# Altium schematic sheet Sheet2.SchDoc
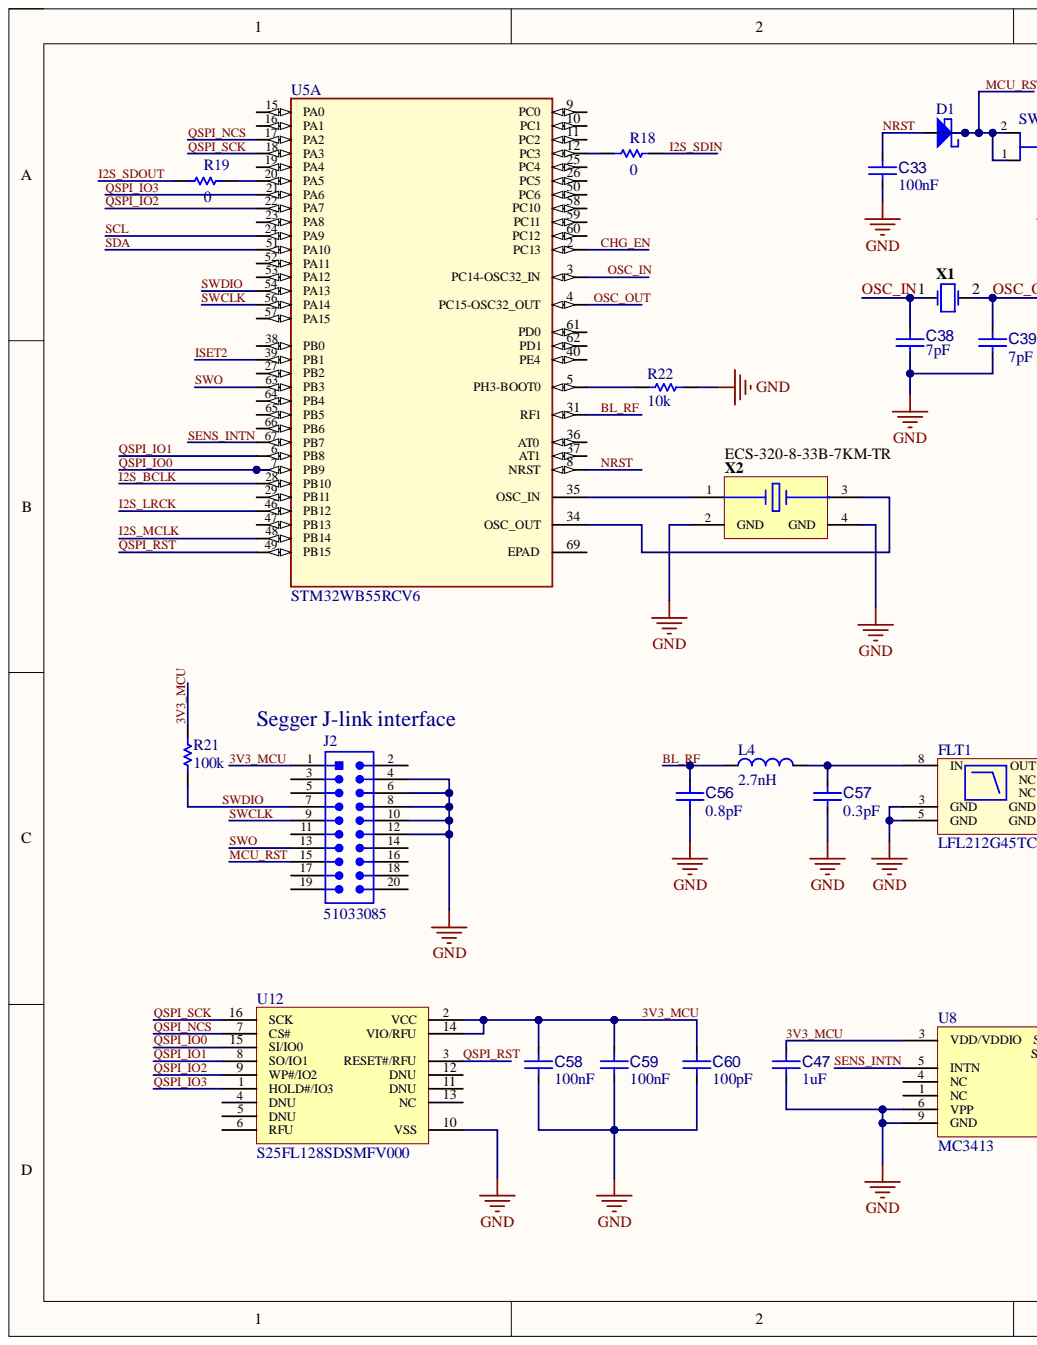
[diagram p0: Sheet2.SchDoc, left]
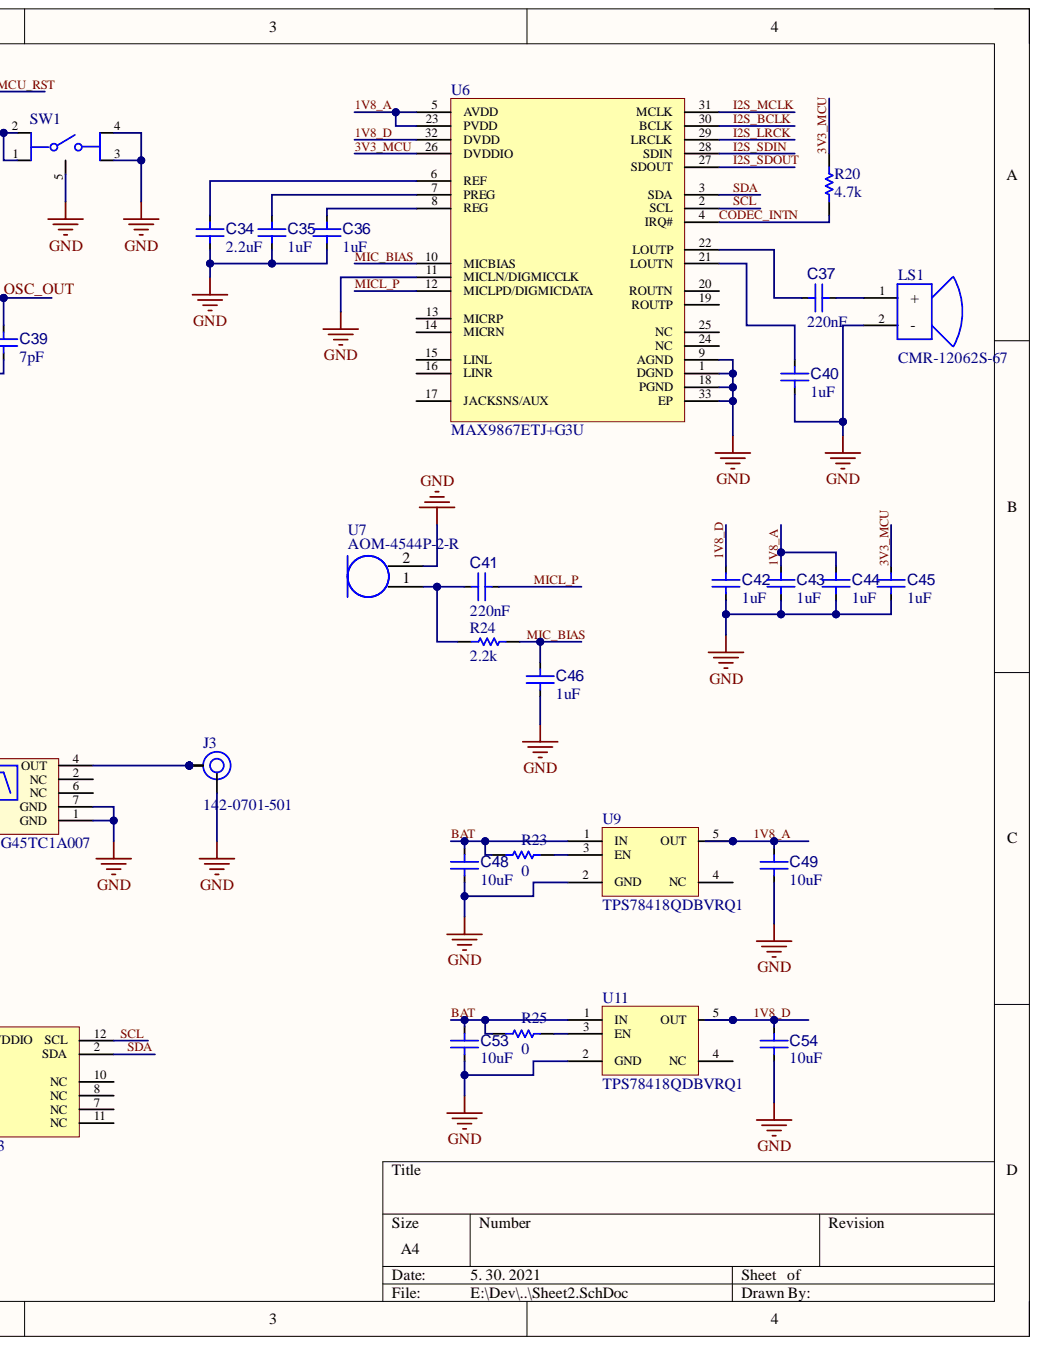
[diagram p1: Sheet2.SchDoc, right]

## Parts
C33  value 100nF  [0402-SMD-CAP]  (hidden comment: EMF105B7104MVHF)
C34  value 2.2uF  Capacitance: 2.2uF  [0603-SMD-CAP]  (hidden comment: GRM188R71A225KE15D)
C35  value 1uF  Capacitance: 1uF  [0603-SMD-CAP]  (hidden comment: C0603C105K3PACTU)
C36  value 1uF  Capacitance: 1uF  [0603-SMD-CAP]  (hidden comment: C0603C105K3PACTU)
C37  value 220nF  Capacitance: 220nF  [0402-SMD-CAP]  (hidden comment: GRM155R71C224KA12D)
C38  value 7pF  Capacitance: 7pF  [0402-SMD-CAP]  (hidden comment: 04025A7R0CAT2A)
C39  value 7pF  Capacitance: 7pF  [0402-SMD-CAP]  (hidden comment: 04025A7R0CAT2A)
C40  value 1uF  Capacitance: 1uF  [0603-SMD-CAP]  (hidden comment: C0603C105K3PACTU)
C41  value 220nF  Capacitance: 220nF  [0402-SMD-CAP]  (hidden comment: GRM155R71C224KA12D)
C42  value 1uF  Capacitance: 1uF  [0603-SMD-CAP]  (hidden comment: C0603C105K3PACTU)
C43  value 1uF  Capacitance: 1uF  [0603-SMD-CAP]  (hidden comment: C0603C105K3PACTU)
C44  value 1uF  Capacitance: 1uF  [0603-SMD-CAP]  (hidden comment: C0603C105K3PACTU)
C45  value 1uF  Capacitance: 1uF  [0603-SMD-CAP]  (hidden comment: C0603C105K3PACTU)
C46  value 1uF  Capacitance: 1uF  [0603-SMD-CAP]  (hidden comment: C0603C105K3PACTU)
C47  value 1uF  Capacitance: 1uF  [0603-SMD-CAP]  (hidden comment: C0603C105K3PACTU)
C48  value 10uF  Capacitance: 10uF  [0805-SMD-CAP]  (hidden comment: C0805C106K8PACTU)
C49  value 10uF  Capacitance: 10uF  [0805-SMD-CAP]  (hidden comment: C0805C106K8PACTU)
C53  value 10uF  Capacitance: 10uF  [0805-SMD-CAP]  (hidden comment: C0805C106K8PACTU)
C54  value 10uF  Capacitance: 10uF  [0805-SMD-CAP]  (hidden comment: C0805C106K8PACTU)
C56  value 0.8pF  Capacitance: 0.8pF  [0402-SMD-CAP]  (hidden comment: GJM1555C1HR80BB01D)
C57  value 0.3pF  Capacitance: 0.3pF  [0402-SMD-CAP]  (hidden comment: GJM1555C1HR30WB01D)
C58  value 100nF  [0402-SMD-CAP]  (hidden comment: EMF105B7104MVHF)
C59  value 100nF  [0402-SMD-CAP]  (hidden comment: EMF105B7104MVHF)
C60  value 100pF  Capacitance: 100pF  [0402-SMD-CAP]  (hidden comment: 04025A101JAT2A)
D1  SS12-E3/61T  [VISH-DO-214AC_V]  (value not drawn)
FLT1  LFL212G45TC1A007  [LFL212G45TC1A007-Footprint-1]
J2  51033085  [51033085-Footprint-1]
J3  142-0701-501  [142-0701-501-Footprint-1]
L4  value 2.7nH  Inductance: 2.7nH  [0402-SMD-IND]  (hidden comment: LQG15HS2N7S02D)
LS1  CMR-12062S-67  [CMR-12062S-67-Footprint-1]
R18  value 0  [0603-SMD-RES]  (hidden comment: Jumper 0603)
R19  value 0  [0603-SMD-RES]  (hidden comment: Jumper 0603)
R20  value 4.7k  Resistance: 4.7k  [0603-SMD-RES]  (hidden comment: ERJ-3EKF4701V)
R21  value 100k  Resistance: 100k  [0603-SMD-RES]  (hidden comment: Resistor 100k +/-1% 0603 100 mW)
R22  value 10k  [0402_SMD_RES]  (hidden comment: CRCW040210K0FKTD)
R23  value 0  [0603-SMD-RES]  (hidden comment: Jumper 0603)
R24  value 2.2k  [0603-SMD-RES]  (hidden comment: CRCW06032K20FKEA)
R25  value 0  [0603-SMD-RES]  (hidden comment: Jumper 0603)
SW1  KMR231GLFS  [FP-KMR231GLFS-MFG]  (value not drawn)
U5  STM32WB55RCV6  [QFN40P800X800X100_HS-69N]  (part A)
U6  MAX9867ETJ+G3U  [QFN50P500X500X80_HS-33N]
U7  AOM-4544P-2-R  [AOM-4544P-2-R-Footprint-1]
U8  MC3413  [MC3413 -Footprint-1]
U9  TPS78418QDBVRQ1  [SOT23127P600-8N]
U11  TPS78418QDBVRQ1  [SOT23127P600-8N]
U12  S25FL128SDSMFV000  [SOIC127P1030X265-16M]
X1  ECS-.327-7-12-TR  [ECS-.327-7-12-TR-Footprint-1]  (value not drawn)
X2  ECS-320-8-33B-7KM-TR  [ECS-320-8-33B-7KM-TR-Footprint-1]

## Nets
GND: C33.2 C34.2 C35.2 C36.2 C38.1 C39.1 C40.2 C42.2 C43.2 C44.2 C45.2 C46.2 C47.2 C48.2 C49.2 C53.2 C54.2 C56.2 C57.2 C58.2 C59.2 C60.2 FLT1.1(GND) FLT1.3(GND) FLT1.5(GND) FLT1.7(GND) J2.4 J2.6 J2.8 J2.10 J2.12 J3.1 LS1.2 R22.1 SW1.3 SW1.4 SW1.5 U6.1(DGND) U6.9(AGND) U6.11(MICLN/DIGMICCLK) U6.18(PGND) U6.33(EP) U7.2 U8.6(VPP) U8.9(GND) U9.2(GND) U11.2(GND) U12.10(VSS) X2.2(GND) X2.4(GND)
1V8_A: C43.1 C44.1 C49.1 U6.5(AVDD) U6.23(PVDD) U9.5(OUT)
1V8_D: C42.1 C54.1 U6.32(DVDD) U11.5(OUT)
3V3_MCU: C45.1 C47.1 C58.1 C59.1 C60.1 J2.1 R20.2 R21.2 U6.26(DVDDIO) U8.3(VDD/VDDIO) U12.2(VCC) U12.14(VIO/RFU)
BAT: C48.1 C53.1 R23.1 R25.1 U9.1(IN) U11.1(IN)
BL_RF: C56.1 L4.1 U5.31(RF1)
CHG_EN: U5.2(PC13)
CODEC_INTN: R20.1 U6.4(IRQ#)
I2S_BCLK: U5.28(PB10) U6.30(BCLK)
I2S_LRCK: U5.46(PB12) U6.29(LRCLK)
I2S_MCLK: U5.48(PB14) U6.31(MCLK)
I2S_SDIN: R18.2 U6.28(SDIN)
I2S_SDOUT: R19.1 U6.27(SDOUT)
ISET2: U5.39(PB1)
MCU_RST: D1.2 J2.15 SW1.1 SW1.2
MIC_BIAS: C46.1 R24.2 U6.10(MICBIAS)
MICL_P: C41.2 U6.12(MICLPD/DIGMICDATA)
NRST: C33.1 D1.1 U5.8(NRST)
OSC_IN: C38.2 U5.3(PC14-OSC32_IN) X1.1
OSC_OUT: C39.2 U5.4(PC15-OSC32_OUT) X1.2
QSPI_IO0: U5.7(PB9) U12.15(SI/IO0)
QSPI_IO1: U5.6(PB8) U12.8(SO/IO1)
QSPI_IO2: U5.22(PA7) U12.9(WP#/IO2)
QSPI_IO3: U5.21(PA6) U12.1(HOLD#/IO3)
QSPI_NCS: U5.17(PA2) U12.7(CS#)
QSPI_RST: U5.49(PB15) U12.3(RESET#/RFU)
QSPI_SCK: U5.18(PA3) U12.16(SCK)
SCL: U5.24(PA9) U6.2(SCL) U8.12(SCL)
SDA: U5.51(PA10) U6.3(SDA) U8.2(SDA)
SENS_INTN: U5.67(PB7) U8.5(INTN)
SWCLK: J2.9 U5.56(PA14)
SWDIO: J2.7 R21.1 U5.54(PA13)
SWO: J2.13 U5.63(PB3)
NetC34_1: C34.1 U6.6(REF)
NetC35_1: C35.1 U6.7(PREG)
NetC36_1: C36.1 U6.8(REG)
NetC37_1: C37.1 U6.22(LOUTP)
NetC37_2: C37.2 LS1.1
NetC40_1: C40.1 U6.21(LOUTN)
NetC41_1: C41.1 R24.1 U7.1
NetC57_1: C57.1 FLT1.8(IN) L4.2
NetFLT1_4: FLT1.4(OUT) J3.2 J3.3 J3.4 J3.5
NetR18_1: R18.1 U5.12(PC3)
NetR19_2: R19.2 U5.20(PA5)
NetR22_2: R22.2 U5.5(PH3-BOOT0)
NetR23_2: R23.2 U9.3(EN)
NetR25_2: R25.2 U11.3(EN)
NetU5_34: U5.34(OSC_OUT) X2.3
NetU5_35: U5.35(OSC_IN) X2.1

Not connected: FLT1 2(NC) 6(NC); J2 2 3 5 11 14 16 17 18 19 20; U5 9(PC0) 10(PC1) 11(PC2) 15(PA0) 16(PA1) 19(PA4) 23(PA8) 25(PC4) 26(PC5) 27(PB2) 29(PB11) 36(AT0) 37(AT1) 38(PB0) 40(PE4) 47(PB13) 50(PC6) 52(PA11) 53(PA12) 57(PA15) 58(PC10) 59(PC11) 60(PC12) 61(PD0) 62(PD1) 64(PB4) 65(PB5) 66(PB6) 69(EPAD); U6 13(MICRP) 14(MICRN) 15(LINL) 16(LINR) 17(JACKSNS/AUX) 19(ROUTP) 20(ROUTN) 24(NC) 25(NC); U8 1(NC) 4(NC) 7(NC) 8(NC) 10(NC) 11(NC); U9 4(NC); U11 4(NC); U12 4(DNU) 5(DNU) 6(RFU) 11(DNU) 12(DNU) 13(NC)

## Library data of the parts
C33 C58 C59 = CMP-001-00001-14: Chip Capacitor, 0.1 uF, +/- 5%, 16 V, 0402 (1005 Metric); Capacitor Type: Ceramic; Voltage Rating: 16V; Case/Package: 0402; Component Kind: Standard; Max Operating Temperature: 125°C; Mfr. Part No.: EMF105B7104MVHF; Min Operating Temperature: -55°C; Mounting Technology: SM; Pins: 2; RoHS Compliant: True; Tolerance: 5%; Library Name: Budapest University of Technology and Economics
C34 = CMP-001-00083-9: CAP CER 2.2UF 10V X7R 0603; Material: Ceramic; Tolerance: 10%; Case Code (Imperial): 0603; Case Code (Metric): 1608; Case/Package: 0603; Component Kind: Standard; Depth: 0.8mm; Dielectric: X7R; Height: 0.8mm; Lead Free: Lead Free; Length: 1.6mm; Max Operating Temperature: 125°C; Min Operating Temperature: -55°C; Mount: Surface Mount; Number of Pins: 2; Package Quantity: 4000; Packaging: Cut Tape; REACH SVHC: No SVHC; RoHS Compliant: Yes; Series: GRM; Termination: Standard; Thickness: 0.035inch; Voltage: 10V; Voltage Rating: 10V; Voltage Rating (DC): 10V; Weight: 0.000222oz; Width: 0.8mm; Library Name: Budapest University of Technology and Economics
C35 C36 C40 C42-C47 = CMP-001-00097-3: KEMET - C0603C105K3PACTU - SMD Multilayer Ceramic Capacitor, 1 µF, 25 V, 0603 [1608 Metric], ± 10%, X5R, C Series KEMET; Voltage: 25V; Case Code (Imperial): 0603; Case Code (Metric): 1608; Case/Package: 0603; Component Kind: Standard; Construction: Flat; Contact Plating: Tin,Matte; Depth: 0.8mm; Dielectric: X5R; Dielectric Material: Ceramic; Height: 0.8mm; Lead Free: Lead Free; Length: 1.6mm; Max Operating Temperature: 85°C; Mfr. Part No.: C0603C105K3PACTU; Min Operating Temperature: -55°C; Mount: Surface Mount,PCB; Number of Pins: 2; Package Quantity: 4000; Packaging: Tape and Reel; Radiation Hardening: No; REACH SVHC: No SVHC; RoHS Compliant: Yes; Series: C0603C; Termination: SMD/SMT; Thickness: 0.034inch; Tolerance: 10%; Voltage Rating: 25V; Voltage Rating (DC): 25V; Width: 0.031inch; Library Name: Budapest University of Technology and Economics
C37 C41 = CMP-001-00090-10: MURATA - GRM155R71C224KA12D - SMD Multilayer Ceramic Capacitor, 0.22 µF, 16 V, 0402 [1005 Metric], ± 10%, X7R, GRM Series; Voltage: 16V; Case Code (Imperial): 0402; Case Code (Metric): 1005; Case/Package: 0402; Component Kind: Standard; Construction: Flat; Depth: 0.5mm; Dielectric: X7R; Height: 0.5mm; Lead Free: Lead Free; Length: 1mm; Material: Ceramic; Max Operating Temperature: 125°C; Min Operating Temperature: -55°C; Mount: Surface Mount; Package Quantity: 1; Packaging: Tape and Reel; Radiation Hardening: No; REACH SVHC: No SVHC; RoHS Compliant: Yes; Series: GRM; Termination: SMD/SMT; Thickness: 0.022inch; Tolerance: 10%; Voltage Rating: 16V; Voltage Rating (DC): 16V; Width: 0.02inch; Library Name: Budapest University of Technology and Economics
C38 C39 = CMP-001-00007-1: Cap Ceramic 7pF 50V C0G 0.25pF SMD 0402 125C T/R; Temperature Coefficient: 30ppm/°C; Case Code (Imperial): 0402; Case Code (Metric): 1005; Case/Package: 0402; Component Kind: Standard; Construction: Flat; Depth: 0.5mm; Dielectric: C0G; Dielectric Material: Ceramic; Lead Free: Lead Free; Length: 1mm; Max Operating Temperature: 125°C; Mfr. Part No.: 04025A7R0CAT2A; Min Operating Temperature: -55°C; Mount: Surface Mount; Package Quantity: 10000; Packaging: Tape and Reel; Radiation Hardening: No; REACH SVHC: No SVHC; Series: -; Termination: SMD/SMT; Thickness: 0.022inch; Voltage: 50V; Voltage Rating: 50V; Voltage Rating (DC): 50V; Width: 0.02inch; Library Name: Budapest University of Technology and Economics
C48 C49 C53 C54 = CMP-001-00096-2: CAP CER 10UF 10V X5R 0805; Dielectric Material: Ceramic; Dissipation Factor: 10%; Case Code (Imperial): 0805; Case Code (Metric): 2012; Case/Package: 0805; Component Kind: Standard; Construction: Flat; Contact Plating: Tin,Matte; Depth: 1.25mm; Dielectric: X5R; Height: 1.25mm; Length: 2mm; Max Operating Temperature: 85°C; Min Operating Temperature: -55°C; Mount: Surface Mount; Number of Pins: 2; Package Quantity: 1; Packaging: Tape and Reel; Radiation Hardening: No; REACH SVHC: No SVHC; RoHS Compliant: Yes; Series: C; Termination: SMD/SMT; Thickness: 0.055inch; Tolerance: 10%; Voltage: 10V; Voltage Rating: 10V; Voltage Rating (DC): 10V; Width: 1.2mm; Library Name: Budapest University of Technology and Economics
C56 = CMP-001-00009-1: Cap Ceramic 0.8pF 50V C0G 0.1pF SMD 0402 125C Paper T/R; Case Code (Imperial): 0402; Case Code (Metric): 1005; Case/Package: 0402; Component Kind: Standard; Construction: Flat; Depth: 0.5mm; Dielectric: C0G; Height: 0.5mm; Lead Free: Lead Free; Length: 1mm; Max Operating Temperature: 125°C; Mfr. Part No.: GJM1555C1HR80BB01D; Min Operating Temperature: -55°C; Mount: Surface Mount; Package Quantity: 1; Packaging: Tape and Reel; Radiation Hardening: No; RoHS Compliant: Yes; Series: GJM; Termination: SMD/SMT; Thickness: 0.022inch; Voltage: 50V; Voltage Rating: 50V; Voltage Rating (DC): 50V; Width: 0.02inch; Library Name: Budapest University of Technology and Economics
C57 = CMP-001-00008-1: Multilayer Ceramic Capacitors MLCC - SMD/SMT 0402 0.3pF 50Volts C0G +/-0.05pF; Voltage Rating: 50V; Case Code (Imperial): 0402; Case Code (Metric): 1005; Case/Package: 0402; Component Kind: Standard; Construction: Flat; Depth: 0.5mm; Dielectric: C0G; Height: 0.5mm; Lead Free: Lead Free; Length: 1mm; Max Operating Temperature: 125°C; Mfr. Part No.: GJM1555C1HR30WB01D; Min Operating Temperature: -55°C; Mount: Surface Mount; Package Quantity: 10000; Packaging: Tape and Reel; Radiation Hardening: No; RoHS Compliant: Yes; Series: GJM; Termination: SMD/SMT; Thickness: 0.022inch; Voltage Rating (DC): 50V; Width: 0.02inch; Library Name: Budapest University of Technology and Economics
C60 = CMP-001-00004-1: Capacitor, Ceramic; 100pf; Chip; Case 0402; Npo; +/-5%; 50wvdc; Smd; 2.5% Df; Tr; Dielectric: C0G; Insulation Resistance: 1GR; Temperature Coefficient: 30ppm/°C; Tolerance: 5%; Voltage: 50V; Case Code (Imperial): 0402; Case Code (Metric): 1005; Case/Package: 0402; Component Kind: Standard; Construction: Flat; Contact Plating: Tin,Matte; Depth: 0.5mm; Dissipation Factor: 2.5%; Height: 0.56mm; Lead Free: Lead Free; Length: 1mm; Max Operating Temperature: 125°C; Mfr. Part No.: 04025A101JAT2A; Min Operating Temperature: -55°C; Mount: Surface Mount,PCB; Package Quantity: 1; Packaging: Tape and Reel; Radiation Hardening: No; REACH SVHC: No SVHC; RoHS Compliant: Yes; Series: -; Termination: SMD/SMT; Thickness: 0.022inch; Voltage Rating: 50V; Voltage Rating (DC): 50V; Width: 0.02inch; Library Name: Budapest University of Technology and Economics
D1 = CMP-004-00017-3: Schottky Barrier Rectifier, 20 V, 1 A, -65 to 150 degC, 2-Pin DO-214AC (SMA), RoHS, Tape and Reel; Manufacturer: Vishay Semiconductor; Forward Current: 1A; Forward Voltage: 500mV; Max Surge Current: 40A; Peak Reverse Current: 6mA; Case/Package: SMA; Component Kind: Standard; Datasheet URL: http://www.vishay.com/docs/88746/ss12.pdf; Datasheet Version: Aug-15; Manufacturer URL: http://www.vishay.com/; Max Operating Temperature: 125°C; Min Operating Temperature: -65°C; Mounting Technology: SM; Package Description: 2-Pin Surface Mount Device, Body 4.245 x 2.665 mm; Package Quantity: 1800; Package Reference: DO-214AC (SMA); Packaging: TapeandReel; Pins: 2; RoHS Compliant: True; Library Name: Budapest University of Technology and Economics
FLT1 = CMP-00004-1: CHIP MULTILAYER LC FILTER; Bandwidth: 50MHz; Frequency: 2.45GHz; Impedance: 50R; Insertion Loss: 0.5dB; Max Frequency: 2.5GHz; Min Frequency: 2.4GHz; Shielding: Unshielded; Case Code (Imperial): 0805; Case Code (Metric): 2012; Case/Package: 0805; Component Kind: Standard; Lead Free: Lead Free; Length: 2mm; Max Operating Temperature: 85°C; Min Operating Temperature: -40°C; Number of Pins: 8; Package Quantity: 1; Packaging: Tape and Reel; Radiation Hardening: No; REACH SVHC: No SVHC; RoHS Compliant: Yes; Series: LFL; Termination: SMD/SMT; Width: 1.25mm; Library Name: Budapest University of Technology and Economics
J2 = CMP-002-00088-2: CONN HEADER VERT 20POS 2.54MM; Board Standoff: No (Without); Connector System: Wire-to-Board; Contact Current Rating: 1A; Contact Post Length: 0.12inch; Insulation Resistance: 5GR; Mating Alignment: Yes (With); Max Voltage Rating (AC): 250V; Number of Contacts: 20; PCB Mount Retention: Without; PCB Mounting Orientation: Vertical; Color: Black; Component Kind: Standard; Connector Type: Header; Contact Gender: Male; Contact Material: Copper Alloy; Contact Mating Length: 0.24inch; Contact Plating: Gold,Tin; Contact Shape: Square; Contact Type: Male Pin; Current Rating: 1A; Depth: 9.14mm; Flammability Rating: UL94 V-0; Height: 8.813mm; Housing Color: Black; Housing Material: PBT; Length: 33.02mm; Mating Connector Lock: No (Without); Mating Retention: No (Without); Max Operating Temperature: 105°C; Min Operating Temperature: -65°C; Mount: Through Hole; Number of Positions: 20; Number of Rows: 2; Orientation: Straight; Package Quantity: 35; Packaging: Rail/Tube; PCB Mount Alignment: No (Without); Pins: 20; Pitch: 2.54mm; REACH SVHC: No SVHC; Row Spacing: 2.54mm; Series: AMP-LATCH; Shrouded: Yes (With); Shrouded End Dimension: 3.81mm; Termination: Through Hole; Voltage Rating: 250V; Library Name: Budapest University of Technology and Economics
J3 = CMP-002-00082-2: CONN SMA JACK R/A 50 OHM PCB; Body Material: Brass; Body Plating: Gold; Connector Type: SMA,Coaxial; Dielectric Material: PTFE; Frequency: 18Hz; Impedance: 50R; Max Frequency: 18GHz; Operating Frequency: 0 to 18 GHz; Voltage Rating: 335V; Case/Package: SMA; Center Contact Plating: Gold; Component Kind: Standard; Contact Material: Copper; Contact Plating: Gold; Fastening Type: Threaded; Housing Color: Gold; Length: 19.69mm; Max Operating Temperature: 165°C; Min Operating Temperature: -65°C; Mount: Through Hole,Panel,Bulkhead; Orientation: Right Angle; Package Quantity: 25; Packaging: Bulk; Radiation Hardening: No; REACH SVHC: No SVHC; Series: SMA; Termination: Solder; Type: SMA; Library Name: Budapest University of Technology and Economics
L4 = CMP-006-00031-1: MURATA - LQG15HS2N7S02D - INDUCTOR, 2.7NH, 0.8A, 0402, MULTILAYER; Core Material: Air; Current Rating: 300mA; DC Resistance (DCR): 150mR; Q Factor: 8; Self Resonant Frequency: 6GHz; Shielding: Unshielded; Test Frequency: 100MHz; Case Code (Imperial): 0402; Case Code (Metric): 1005; Case/Package: 0402; Component Kind: Standard; DC Current: 300mA; Depth: 0.55mm; Height: 0.5mm; Height - Seated (Max): 0.022inch; Lead Free: Lead Free; Length: 1mm; Max DC Current: 300mA; Max Operating Temperature: 125°C; Mfr. Part No.: LQG15HS2N7S02D; Military Standard: Not; Min Operating Temperature: -55°C; Mount: Surface Mount; Package Quantity: 10000; Packaging: Tape and Reel; Radiation Hardening: No; Rated Current-Max: 300mA; RoHS Compliant: Yes; Series: LQG15HS; Termination: SMD/SMT; Width: 0.5mm; Library Name: Budapest University of Technology and Economics
LS1 = CMP-00027-00003-2: SPEAKER, 12 X 6 MM, 2 MM DEEP, M; Manufacturer: CUI Devices; Frequency: 540Hz; Impedance: 32R; IP Rating: IP67; Sound Pressure Level: 120dB; Component Kind: Standard; Mfr. Part No.: CMR-12062S-67; Library Name: Budapest University of Technology and Economics
R18 R19 R23 R25 = CMP-009-00175-3: Case/Package: 0603; Component Kind: Standard; Description: Jumper 0603; Max Operating Temperature: 155°C; Min Operating Temperature: -55°C; Pins: 2; Library Name: Budapest University of Technology and Economics
R20 = CMP-009-00190-2: RESISTOR, 0603, 0.1W, 1%, 4K7; Max Power Dissipation: 100mW; Temperature Coefficient: 100ppm/°C; Voltage Rating: 75V; Case Code (Imperial): 0603; Case Code (Metric): 1608; Case/Package: 0603; Component Kind: Standard; Construction: Rectangular; Contact Plating: Tin; Depth: 0.8mm; Features: Automotive AEC-Q200; Height: 0.022inch; Lead Free: Lead Free; Length: 1.6mm; Max Operating Temperature: 155°C; Military Standard: Not; Min Operating Temperature: -55°C; Mount: Surface Mount; Number of Pins: 2; Number of Terminations: 2; Package Quantity: 1; Packaging: Tape and Reel; Power Rating: 100mW; Radiation Hardening: No; REACH SVHC: No SVHC; Resistance Tolerance: 1%; Resistor Type: Thick Film; RoHS Compliant: Yes; Series: ERJ; Size Code: 0603; Termination: SMD/SMT; Tolerance: 1%; Voltage Rating (DC): 75V; Weight: 7.1E-05oz; Width: 0.031inch; Library Name: Budapest University of Technology and Economics
R21 = CMP-009-00023-7: Chip Resistor, 100 KOhm, +/- 1%, 0.1 W, -55 to 155 degC, 0603 (1608 Metric); Power: 100mW; Voltage Rating: 50V; Case/Package: 0603; Component Kind: Standard; Max Operating Temperature: 155°C; Min Operating Temperature: -55°C; Mounting Technology: SM; Pins: 2; RoHS Compliant: True; Tolerance: 1%; Library Name: Budapest University of Technology and Economics
R22 = CMP-009-00149-11: Chip Resistor, 10 kOhms, +/-1 %, 63 mW, -55 to 125 degC, 0402; Power: 63mW; Tolerance: 1%; Case/Package: 0402; Component Kind: Standard; Max Operating Temperature: 155°C; Mfr. Part No.: CRCW040210K0FKTD; Min Operating Temperature: -55°C; Pins: 2; Library Name: Budapest University of Technology and Economics
R24 = CMP-009-00006-2: SMD Resistor, Thick film 2.2 kOhm,  ±  1 %, 0603, CRCW06032K20FKEA, Vishay; Max Power Dissipation: 100mW; Resistance: 2.2kR; Resistance Tolerance: 1%; Temperature Coefficient: 100ppm/°C; Voltage Rating: 75V; Case Code (Imperial): 0603; Case Code (Metric): 1608; Case/Package: 0603; Component Kind: Standard; Construction: Rectangular; Contact Plating: Tin; Depth: 0.85mm; Features: Automotive AEC-Q200; Height: 0.45mm; Lead Free: Lead Free; Length: 1.55mm; Max Operating Temperature: 155°C; Mfr. Part No.: CRCW06032K20FKEA; Military Standard: Not; Min Operating Temperature: -55°C; Mount: Surface Mount; Number of Terminations: 2; Package Quantity: 10; Packaging: Tape and Reel; Power Rating: 100mW; Radiation Hardening: No; REACH SVHC: Unknown; Resistor Type: Thick Film; RoHS Compliant: Yes; Series: CRCW; Size Code: 0603; Technology: THICK FILM; Termination: SMD/SMT; Tolerance: 1%; Voltage Rating (DC): 75V; Weight: 7.1E-05oz; Width: 0.033inch; Library Name: Budapest University of Technology and Economics
SW1 = CMP-011-00013-2: Switch Tactile N.O. SPST Button Gull Wing 0.05A 32VDC 1VA 150000Cycles 3N SMD T/R; Actuator Length: 0.5mm; Actuator Type: Standard; Contact Current Rating: 50mA; Contact Resistance: 100mR; Illumination Type: Non-Illuminated,Illuminated; Insulation Resistance: 1GR; Max Voltage Rating (DC): 32V; Power Rating: 1W; Switch Function: Off-Mom; Switch Travel: 0.25mm; Case/Package: SMD/SMT; Component Kind: Standard; Contact Material: Silver; Contact Plating: Silver; Current Rating: 50mA; Depth: 4.6mm; Lead Free: Lead Free; Length: 4.6mm; Max Current Rating: 50mA; Max Operating Temperature: 85°C; Mechanical Life: 150000Cycles; Min Operating Temperature: -40°C; Mount: Surface Mount; Operating Force: 3N; Orientation: Straight; Package Quantity: 7000; Packaging: Cut Tape; Radiation Hardening: No; REACH SVHC: No SVHC; Series: KMR2; Termination: Gull Wing; Throw Configuration: SPST; Voltage Rating (DC): 32V; Width: 2.8mm; Library Name: Budapest University of Technology and Economics
U5 = CMP-020-00023-5: ARM Based Multiprotocol Wireless Microcontroller 32-Bit 1.71V to 3.6V 68-Pin 256KB VFQFPN Surface Mount; Manufacturer: ST; Frequence: 64MHz; Case/Package: VFQFPN; Component Kind: Standard; Flash size: 256kB; Max Operating Temperature: 85°C; Max Supply Voltage: 3.6V; Min Operating Temperature: -40°C; Min Supply Voltage: 1.71V; Library Name: Budapest University of Technology and Economics
U6 = CMP-001-0002-4: MAX9867 Series 1.8V I2C/Serial Ultra-Low Power Stereo Audio Codec - TQFN-32EP; Interface: I2C,Serial; Number of D/A Converters: 2; Resolution: 18b; Sampling Rate: 48KS/s; Signal to Noise Ratio (SNR): 85dB; Component Kind: Standard; Max Operating Temperature: 85°C; Mfr. Part No.: MAX9867ETJ+G3U; Min Operating Temperature: -40°C; Mount: Surface Mount; Number of Pins: 32; Operating Supply Voltage: 1.8V; Package Quantity: 60; Packaging: Rail/Tube; RoHS Compliant: Yes; Type: General Purpose; Library Name: Budapest University of Technology and Economics
U7 = CMP-00027-00002-1: Microphones 2.2K OHM 9.7mm; Current Rating: 500uA; Direction: Omnidirectional; Impedance: 2.2kR; Max Supply Voltage: 1.5V; Max Supply Voltage (DC): 10V; Output Type: Analog; Shape: Circular,Round; Component Kind: Standard; Depth: 4.5mm; Diameter: 9.7mm; Height: 4.7mm; Length: 9.7mm; Mount: Wire; Nominal Supply Voltage (DC): 1.5V; Operating Supply Current: 500uA; Output Impedance: 2.2kR; Package Quantity: 100; Packaging: Bulk; REACH SVHC: No SVHC; RoHS Compliant: Yes; Series: AOM; Termination: PC Pins; Voltage Rating: 10V; Width: 9.7mm; Library Name: Budapest University of Technology and Economics
U8 = CMP-00002-2: 3-AXIS Iomt Accelerometer (1.6X1; Manufacturer: MEMSIC; Acceleration: 2g, 4g, 8g, 12g, 16g; Interface Type: I2C; Sensing Axis: X,Y,Z; Case/Package: VLGA-12; Component Kind: Standard; Mfr. Part No.: MC3413; Type: Accelerometer; Library Name: Budapest University of Technology and Economics
U9 U11 = CMP-019-00061-1: Automotive, 300-mA, low-IQ, high-PSRR, LDO voltage regulator with high accuracy and enable 5-SOT-23 -40 to 150; Manufacturer: Texas Instruments; Dropout voltage: 115mV; Max Output Current: 300mA; Output Voltage: 1.8V; Case/Package: SOT-23; Component Kind: Standard; Mfr. Part No.: TPS78418QDBVRQ1; Library Name: Budapest University of Technology and Economics
U12 = CMP-019-00062-1: 128-MBIT Cmos 3.0 Volt Flash Memory With 80MHZ Ddr Spi (ser; Mfr. Part No.: S25FL128SDSMFV001; Interface: SPI,Serial; Memory Type: FLASH,NOR; Case/Package: SOIC; Component Kind: Standard; Frequency: 80MHz; Max Operating Temperature: 105°C; Min Operating Temperature: -40°C; Packaging: Tray; RoHS Compliant: Yes; Library Name: Budapest University of Technology and Economics
X1 = CMP-003-00032-3: CRYSTAL 32.7680KHZ 7PF SMD; ESR (Equivalent Series Resistance): 90kR; Frequency: 32.768kHz; Frequency Stability: 20ppm; Case/Package: SMD/SMT; Component Kind: Standard; Frequency Tolerance: 20ppm; Height: 0.024inch; Lead Pitch: 0.8mm; Length: 0.079inch; Load Capacitance: 7pF; Max Operating Temperature: 85°C; Min Operating Temperature: -40°C; Mount: Surface Mount; Number of Pins: 2; Package Quantity: 3000; Packaging: Tape and Reel; RoHS Compliant: Yes; Series: ECX-12; Termination: SMD/SMT; Width: 0.047inch; Library Name: Budapest University of Technology and Economics
X2 = CMP-003-00031-3: Crystal 32MHz ±7ppm (Tol) ±10ppm (Stability) 8pF FUND 40Ohm 4-Pin Mini-CSMD T/R; Manufaturer: ECS; Drive Level: 200uW; ESR: 40; Case/Package: 3.2x2.5x0.8mm; Component Kind: Standard; Freqeuncy Tolerance: 10ppm; Frequency: 32MHz; Load Capacitance: 8pF; Max Operating Temperature: -20°C; Min Operating Temperature: 70°C; Tolerance: 7ppm; Library Name: Budapest University of Technology and Economics
